# Altium schematic sheet Power.SchDoc(Power)
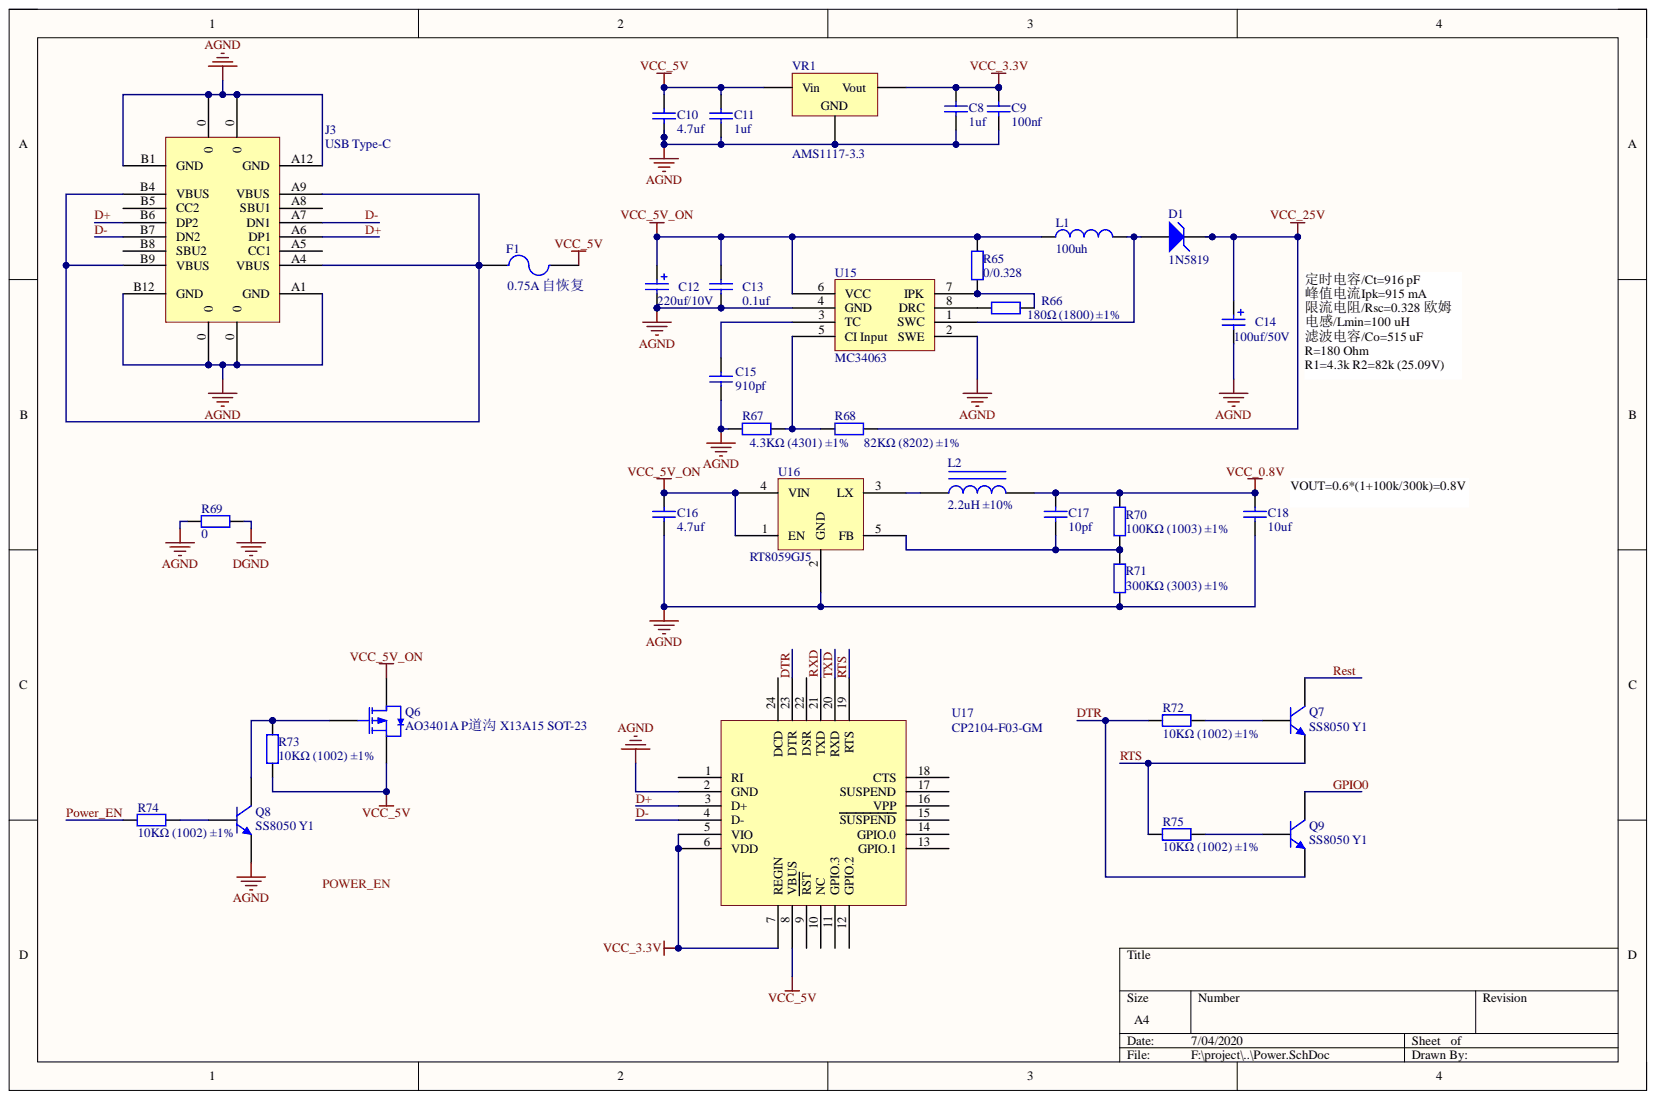
[diagram p0: Power.SchDoc]

## Parts
C8  1uf  [0603_C]
C9  100nf  [0603_C]
C10  4.7uf  [0603_C]
C11  1uf  [0603_C]
C12  220uf/10V  [SMD,6.3x5.4mm]
C13  0.1uf  [0603_C]
C14  100uf/50V  [CAP-SMD_BD10.0-L10.3-W10.3-RD]
C15  910pf  [0603_C]
C16  4.7uf  [0603_C]
C17  10pf  [0603_C]
C18  10uf  [0603_C]
D1  1N5819  [LL-34]
F1  0.75A 自恢复  [0805_L]
J3  USB Type-C  [USB Type-C_16P]
L1  100uh  [5040 - duplicate1]
L2  2.2uH ±10%  [0805_L]
Q6  AO3401A P道沟 X13A15 SOT-23  [SOT-23-3L]
Q7  SS8050 Y1  [SOT-23(SOT-23-3)]
Q8  SS8050 Y1  [SOT-23(SOT-23-3)]
Q9  SS8050 Y1  [SOT-23(SOT-23-3)]
R65  0/0.328  [0603_R]
R66  180Ω (1800) ±1%  [0603_R]
R67  4.3KΩ (4301) ±1%  [0603_R]
R68  82KΩ (8202) ±1%  [0603_R]
R69  0  [0603_R]
R70  100KΩ (1003) ±1%  [0603_R]
R71  300KΩ (3003) ±1%  [0603_R]
R72  10KΩ (1002) ±1%  [0603_R]
R73  10KΩ (1002) ±1%  [0603_R]
R74  10KΩ (1002) ±1%  [0603_R]
R75  10KΩ (1002) ±1%  [0603_R]
U15  MC34063  [SOP-8P]
U16  RT8059GJ5  [SOT-23-5]
U17  CP2104-F03-GM  [QFN-24_4x4x05P]
VR1  AMS1117-3.3  [SOT-223]

## Nets
AGND: C8.1 C9.1 C10.1 C11.1 C12.2 C13.1 C14.2 C15.1 C16.1 C18.1 J3.0 J3.A1(GND) J3.A12(GND) J3.B1(GND) J3.B12(GND) Q8.2 R67.1 R69.2 R71.1 U15.2(SWE) U15.4(GND) U16.2(GND) U17.2(GND) VR1.1
DGND: R69.1
VCC_0.8V: C17.2 C18.2 L2.2 R70.1
VCC_3.3V: C8.2 C9.2 U17.5(VIO) U17.6(VDD) U17.7(REGIN) VR1.2
VCC_5V: C10.2 C11.2 F1.2 Q6.2 R73.1 U17.8(VBUS) VR1.3
VCC_5V_ON: C12.1 C13.2 C16.2 L1.1 Q6.3 R65.2 U15.6(VCC) U16.1(EN) U16.4(VIN)
VCC_25V: C14.1 D1.2 R68.2
D+: J3.A6(DP1) J3.B6(DP2) U17.3(D+)
D-: J3.A7(DN1) J3.B7(DN2) U17.4(D-)
DTR: Q9.2 R72.1 U17.23(DTR)
GPIO0: Q9.3
Power_EN: R74.1
Rest: Q7.3
RTS: Q7.2 R75.1 U17.19(RTS)
RXD: U17.21(TXD)
TXD: U17.20(RXD)
NetC15_2: C15.2 U15.3(TC)
NetC17_1: C17.1 R70.2 R71.2 U16.5(FB)
NetD1_1: D1.1 L1.2 U15.1(SWC)
NetF1_1: F1.1 J3.A4(VBUS) J3.A9(VBUS) J3.B4(VBUS) J3.B9(VBUS)
NetL2_1: L2.1 U16.3(LX)
NetQ6_1: Q6.1 Q8.3 R73.2
NetQ7_1: Q7.1 R72.2
NetQ8_1: Q8.1 R74.2
NetQ9_1: Q9.1 R75.2
NetR65_1: R65.1 R66.2 U15.7(IPK)
NetR66_1: R66.1 U15.8(DRC)
NetR67_2: R67.2 R68.1 U15.5(CI_Input)

Not connected: J3 A5(CC1) A8(SBU1) B5(CC2) B8(SBU2); U17 1(RI) 9(RST) 10(NC) 11(GPIO.3) 12(GPIO.2) 13(GPIO.1) 14(GPIO.0) 15(SUSPEND) 16(VPP) 17(SUSPEND) 18(CTS) 22(DSR) 24(DCD)

## Library data of the parts
C8 C11 C13 C15 = 0603 1uF (105) 10% 50V: 贴片电容; Component Kind: Standard; Library Name: Miscellaneous Devices LC.IntLib; Package: 0603; Supplier: LC; SuppliersPartNumber: C15849
  C8 C11: ComponentLink1Description: 供应商链接; ComponentLink1URL: http://www.szlcsc.com/product/details_16531.html
C9 = 0603 100nF (104) 10% 50V: 贴片电容; Component Kind: Standard; ComponentLink1Description: 供应商链接; ComponentLink1URL: http://www.szlcsc.com/product/details_15331.html; Library Name: Miscellaneous Devices LC.IntLib; Package: 0603; Supplier: LC; SuppliersPartNumber: C14663
C10 C16 = 0603 4.7uF (475) 10% 16V: 贴片电容; Component Kind: Standard; ComponentLink1Description: 供应商链接; ComponentLink1URL: http://www.szlcsc.com/product/details_20375.html; Library Name: Miscellaneous Devices LC.IntLib; Package: 0603; Supplier: LC; SuppliersPartNumber: C19666
C12 C14 = 3528 100uF (107) 10% 6.3V: Component Kind: Standard; Library Name: Miscellaneous Devices LC.IntLib; Package: 3528; Supplier: LC; SuppliersPartNumber: C16133
C17 = 0603 10pF (100) 5% 50V: 贴片电容; Component Kind: Standard; ComponentLink1Description: 供应商链接; ComponentLink1URL: http://www.szlcsc.com/product/details_1986.html; Library Name: Miscellaneous Devices LC.IntLib; Package: 0603; Supplier: LC; SuppliersPartNumber: C1634
C18 = 0603 10uF (106) 10% 10V: 贴片电容; Component Kind: Standard; ComponentLink1Description: 供应商链接; ComponentLink1URL: http://www.szlcsc.com/product/details_20411.html; Library Name: Miscellaneous Devices LC.IntLib; Package: 0603; Supplier: LC; SuppliersPartNumber: C19702
D1 = LL-34 ZMM3V3: 稳压二极管; Component Kind: Standard; ComponentLink1Description: 供应商链接; ComponentLink1URL: http://www.szlcsc.com/product/details_8535.html; Library Name: Miscellaneous Devices LC.IntLib; Package: LL-34; Supplier: LC; SuppliersPartNumber: C8056
F1 = Fuse 2: Fuse; Component Kind: Standard; LatestRevisionDate: 17-Jul-2002; LatestRevisionNote: Re-released for DXP Platform.; Library Name: Miscellaneous Devices.IntLib; PackageDescription: Thru-Hole; 2 Leads; PackageReference: PIN-W2/E2.8; Published: 8-Jun-2000; Simulation: FUSE; Publisher: Altium Limited
J3 = USB Type-C_16P: Component Kind: Standard; Library Name: IV-3a.IntLib
L1 = Inductor: Code_IEC: 1005; Code_JEITA: 0402; Component Kind: Standard; Description: Inductor; LatestRevisionDate: 29-May-2009; LatestRevisionNote: IPC-7351 Footprint Added.; Library Name: Miscellaneous Devices.IntLib; PackageDescription: Chip Inductor; PackageReference: 0402-A; Published: 8-Jun-2000; Signal Integrity: Inductor; Simulation: INDUCTOR; Value: 100uH; Publisher: Altium Limited
L2 = 0805 2.2uH 10%: 贴片电感; Component Kind: Standard; ComponentLink1Description: 供应商链接; ComponentLink1URL: http://www.szlcsc.com/product/details_1395.html; Library Name: Miscellaneous Devices LC.IntLib; Package: 0805; Supplier: LC; SuppliersPartNumber: C1043
Q6 = AO3401A P道沟 X13A15 SOT-23: MOS(场效应管); Component Kind: Standard; ComponentLink1Description: 供应商链接; ComponentLink1URL: http://www.szlcsc.com/product/details_15801.html; Library Name: Miscellaneous Devices LC.IntLib; Package: SOT-23; Supplier: LC; SuppliersPartNumber: C15127
Q7-Q9 = SOT-23 SS8050 Y1: 三极管; Component Kind: Standard; ComponentLink1Description: 供应商链接; ComponentLink1URL: http://www.szlcsc.com/product/details_2507.html; Library Name: Miscellaneous Devices LC.IntLib; Package: SOT-23; Supplier: LC; SuppliersPartNumber: C2150
R65 R66 R69 = 0603 180Ω (1800) 1%: 贴片电阻; Component Kind: Standard; Library Name: Miscellaneous Devices LC.IntLib; Package: 0603; Supplier: LC; SuppliersPartNumber: C22828
  R66: ComponentLink1Description: 供应商链接; ComponentLink1URL: http://www.szlcsc.com/product/details_23555.html
R67 = 0603 4.3KΩ (4301) 1%: 贴片电阻; Component Kind: Standard; ComponentLink1Description: 供应商链接; ComponentLink1URL: http://www.szlcsc.com/product/details_23886.html; Library Name: Miscellaneous Devices LC.IntLib; Package: 0603; Supplier: LC; SuppliersPartNumber: C23159
R68 = 0603 82KΩ (8202) 1%: 贴片电阻; Component Kind: Standard; ComponentLink1Description: 供应商链接; ComponentLink1URL: http://www.szlcsc.com/product/details_23981.html; Library Name: Miscellaneous Devices LC.IntLib; Package: 0603; Supplier: LC; SuppliersPartNumber: C23254
R70 = 0603 100KΩ (1003) 1%: 贴片电阻; Component Kind: Standard; ComponentLink1Description: 供应商链接; ComponentLink1URL: http://www.szlcsc.com/product/details_26546.html; Library Name: Miscellaneous Devices LC.IntLib; Package: 0603; Supplier: LC; SuppliersPartNumber: C25803
R71 = 0603 300KΩ (3003) 1%: 贴片电阻; Component Kind: Standard; ComponentLink1Description: 供应商链接; ComponentLink1URL: http://www.szlcsc.com/product/details_23751.html; Library Name: Miscellaneous Devices LC.IntLib; Package: 0603; Supplier: LC; SuppliersPartNumber: C23024
R72-R75 = 0603 10KΩ (1002) 1%: 贴片电阻; Component Kind: Standard; ComponentLink1Description: 供应商链接; ComponentLink1URL: http://www.szlcsc.com/product/details_26547.html; Library Name: Miscellaneous Devices LC.IntLib; Package: 0603; Supplier: LC; SuppliersPartNumber: C25804
U17 = CP2104-F03-GM_[C47742]: USB; Component Kind: Standard; ComponentLink1Description: 供应商链接; ComponentLink1URL: http://www.szlcsc.com/product/details_48747.html; Library Name: Miscellaneous Devices LC.IntLib; Package: QFN-24_4x4x05P; Supplier: LC; SuppliersPartNumber: C47742
VR1 = SOT-223 AMS1117-3.3: 低压差线性稳压(LDO); Component Kind: Standard; ComponentLink1Description: 供应商链接; ComponentLink1URL: http://www.szlcsc.com/product/details_6652.html; Library Name: Miscellaneous Devices LC.IntLib; Package: SOT-223; Supplier: LC; SuppliersPartNumber: C6186
U15 = MC34063: Component Kind: Standard; Library Name: IV-3a.IntLib
U16 = RT8059GJ5: Component Kind: Standard; Library Name: IV-3a.IntLib
